# Altium schematic sheet PWR_IN.SchDoc
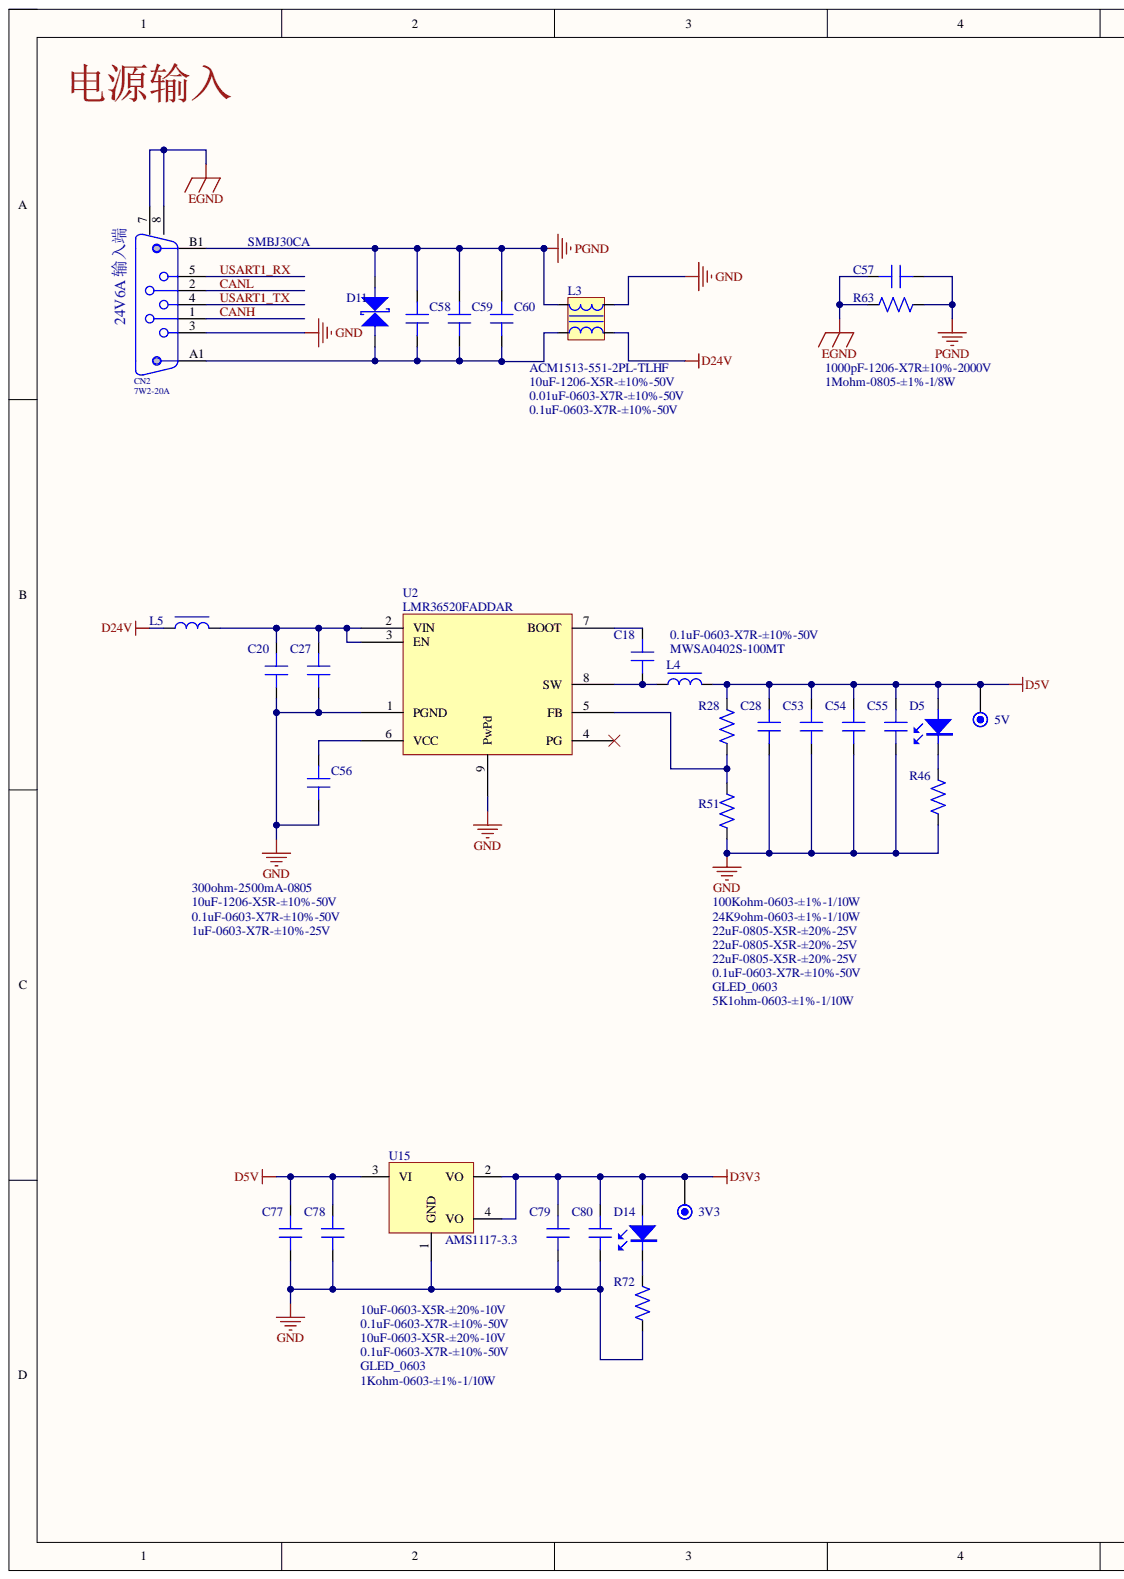
[diagram p0: PWR_IN.SchDoc, left]
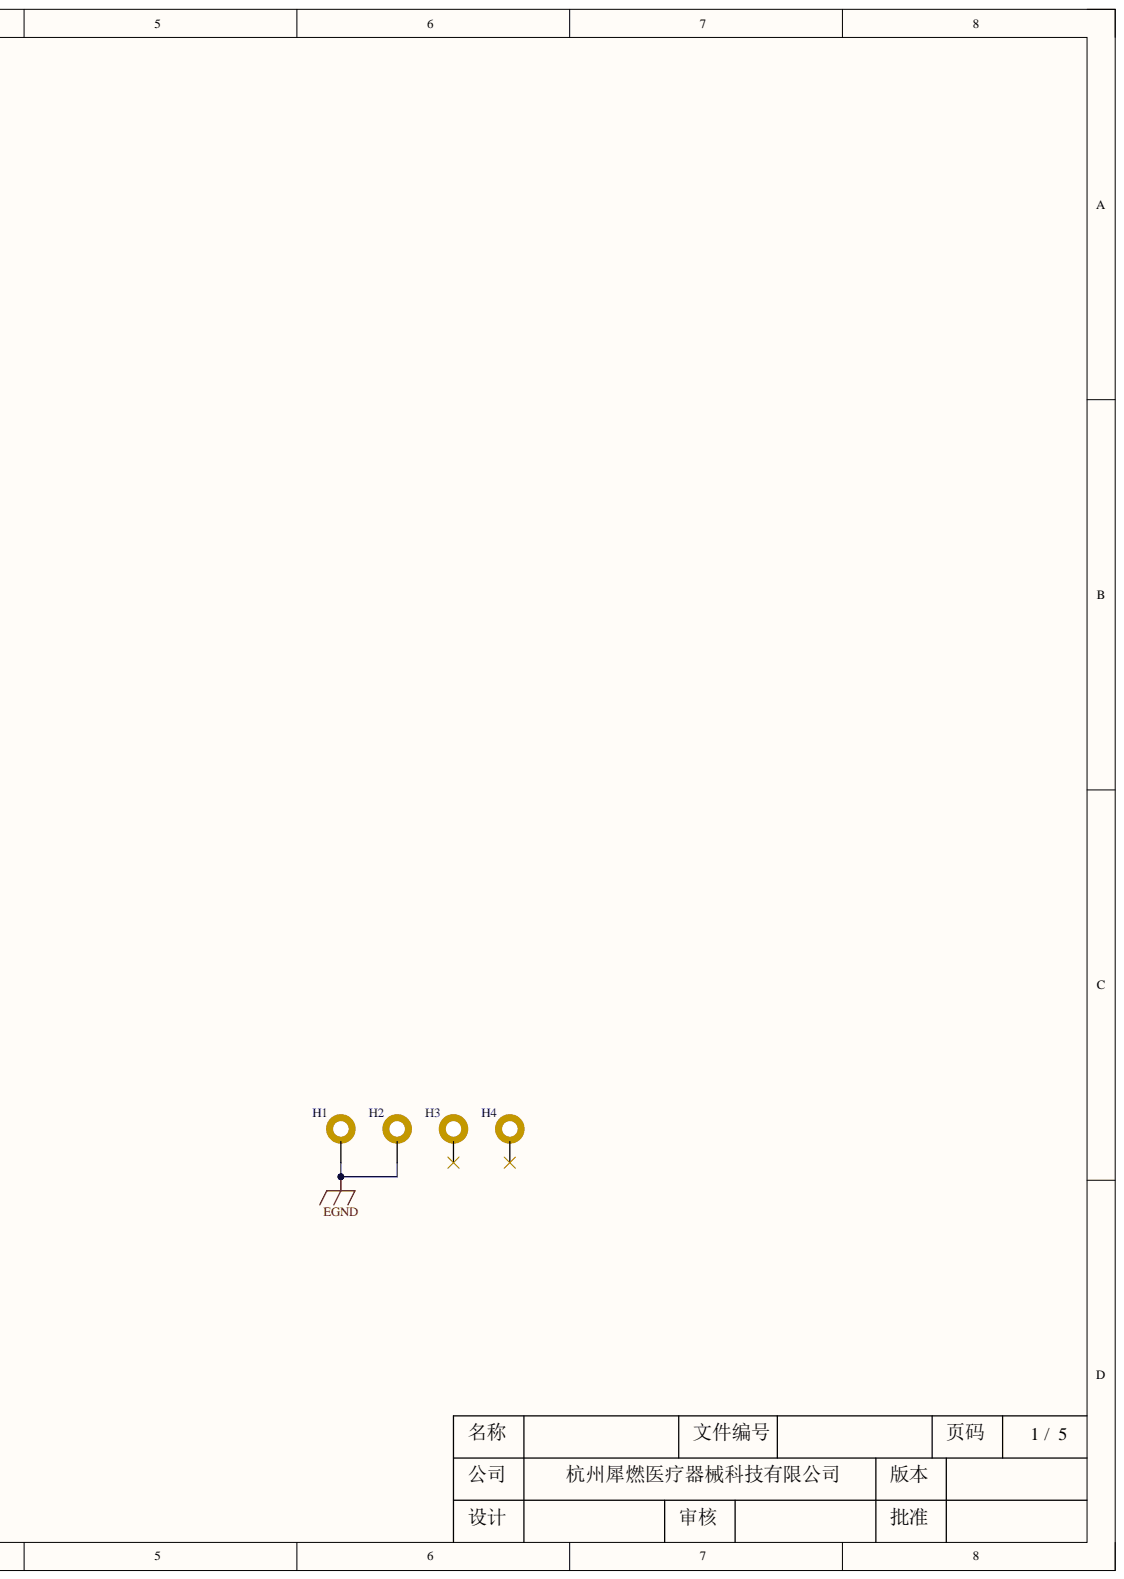
[diagram p1: PWR_IN.SchDoc, right]

## Parts
3V3  TEST  [TP-10]  (value not drawn)
5V  TEST  [TP-10]  (value not drawn)
C18  0.1uF-0603-X7R-±10%-50V  [C0603]
C20  10uF-1206-X5R-±10%-50V  [C1206]
C27  0.1uF-0603-X7R-±10%-50V  [C0603]
C28  22uF-0805-X5R-±20%-25V  [C0805]
C53  22uF-0805-X5R-±20%-25V  [C0805]
C54  22uF-0805-X5R-±20%-25V  [C0805]
C55  0.1uF-0603-X7R-±10%-50V  [C0603]
C56  1uF-0603-X7R-±10%-25V  [C0603]
C57  1000pF-1206-X7R±10%-2000V  [C1206]
C58  10uF-1206-X5R-±10%-50V  [C1206]
C59  0.01uF-0603-X7R-±10%-50V  [C0603]
C60  0.1uF-0603-X7R-±10%-50V  [C0603]
C77  10uF-0603-X5R-±20%-10V  [C0603]
C78  0.1uF-0603-X7R-±10%-50V  [C0603]
C79  10uF-0603-X5R-±20%-10V  [C0603]
C80  0.1uF-0603-X7R-±10%-50V  [C0603]
CN2  7W2-20A  [7W2-40A]
D5  GLED_0603  [LED-S0603]
D11  SMBJ30CA  [DIODE-SMB-1]
D14  GLED_0603  [LED-S0603]
H1  SMTSO3030CTJ  [ASSE4.22C-8]  (value not drawn)
H2  SMTSO3030CTJ  [ASSE4.22C-8]  (value not drawn)
H3  SMTSO3030CTJ  [ASSE4.22C-8]  (value not drawn)
H4  SMTSO3030CTJ  [ASSE4.22C-8]  (value not drawn)
L3  ACM1513-551-2PL-TLHF  [LC4(15.0*13.0)-S]
L4  MWSA0402S-100MT  [L(5*2.0)-S -1]
L5  300ohm-2500mA-0805  [L0805]
R28  100Kohm-0603-±1%-1/10W  [R0603]
R46  5K1ohm-0603-±1%-1/10W  [R0603]
R51  24K9ohm-0603-±1%-1/10W  [R0603]
R63  1Mohm-0805-±1%-1/8W  [R0805]
R72  1Kohm-0603-±1%-1/10W  [R0603]
U2  LMR36520FADDAR  [SOP8/1.27]
U15  AMS1117-3.3  [SOT-223]

## Nets
D3V3: 3V3.1 C79.2 C80.2 D14.A U15.2(VO) U15.4(VO)
D5V: 5V.1 C28.1 C53.1 C54.1 C55.2 C77.2 C78.2 D5.A L4.2 R28.2 U15.3(VI)
D24V: L3.1 L5.1
EGND: C57.1 CN2.7 CN2.8 H1.0 H2.0 R63.1
GND: C20.1 C27.1 C28.2 C53.2 C54.2 C55.1 C56.1 C77.1 C78.1 C79.1 C80.1 CN2.3 L3.2 R46.1 R51.1 R72.1 U2.1(PGND) U2.9(PwPd) U15.1(GND)
PGND: C57.2 C58.1 C59.2 C60.2 CN2.B1 D11.K L3.3 R63.2
CANH: CN2.1
CANL: CN2.2
USART1_RX: CN2.5
USART1_TX: CN2.4
NetC18_1: C18.1 L4.1 U2.8(SW)
NetC18_2: C18.2 U2.7(BOOT)
NetC20_2: C20.2 C27.2 L5.2 U2.2(VIN) U2.3(EN)
NetC56_2: C56.2 U2.6(VCC)
NetC58_2: C58.2 C59.1 C60.1 CN2.A1 D11.A L3.4
NetD5_K: D5.K R46.2
NetD14_K: D14.K R72.2
NetR28_1: R28.1 R51.2 U2.5(FB)

Not connected: H3 0; H4 0; U2 4(PG)

## Library data of the parts
C18 C27 C55 C60 C78 C80 = 0.1uF-0603-X7R-±10%-50V-D: CAP; Component Kind: Standard; Library Name: RCL.SchLib
C20 C58 = 10uF-1206-X5R-±10%-50V-D: Component Kind: Standard; Library Name: RCL.SchLib
C28 C53 C54 = 22uF-0805-X5R-±20%-25V-D: Component Kind: Standard; Library Name: RCL.SchLib
C56 = 1uF-0603-X7R-±10%-25V-D: GCM188R71E105KA64D; Component Kind: Standard; Library Name: RCL.SchLib
C57 = 1000pF-1206-X7R±10%-2000V-D: Component Kind: Standard; Library Name: RCL.SchLib
C59 = 0.01uF-0603-X7R-±10%-50V-D: Component Kind: Standard; Library Name: RCL.SchLib
C77 C79 = 10uF-0603-X5R-±20%-10V-D: Component Kind: Standard; Library Name: RCL.SchLib
CN2 = DSUB con,7W2-20A: 7W2-20A  弯针; Component Kind: Standard; Library Name: Connector.SchLib
D11 = SMBJ30CA-D: Component Kind: Standard; Library Name: Semiconductor.SchLib
H1-H4 = 表贴圆螺母柱: 表贴圆螺母柱SMTSO3030CTJ; Component Kind: Standard; Library Name: Other.SchLib
L3 = ACM1513-551-2PL-TLHF-D: 共模电感; Component Kind: Standard; Library Name: RCL.SchLib
L4 = MWSA0402S-100MT-D: Component Kind: Standard; Library Name: RCL.SchLib
L5 = 300ohm-2500mA-0805-D: Component Kind: Standard; Library Name: RCL.SchLib
R28 = 100Kohm-0603-±1%-1/10W-D: Component Kind: Standard; Library Name: RCL.SchLib
R46 = 5K1ohm-0603-±1%-1/10W-D: Component Kind: Standard; Library Name: RCL.SchLib
R51 = 24K9ohm-0603-±1%-1/10W-D: Component Kind: Standard; Library Name: RCL.SchLib
R63 = 1Mohm-0805-±1%-1/8W-D: RES; Component Kind: Standard; Library Name: RCL.SchLib
R72 = 1Kohm-0603-±1%-1/10W-D: Component Kind: Standard; Library Name: RCL.SchLib
U2 = LMR36520FADDAR-D: Component Kind: Standard; Library Name: check.SchLib
U15 = AMS1117-3.3-D: Component Kind: Standard; Library Name: check.SchLib
3V3 5V = TEST: Component Kind: Standard; Library Name: check.SchLib
D5 D14 = GLED_0603: Component Kind: Standard; Library Name: check.SchLib
